# Altium schematic sheet GradientFilter.SchDoc
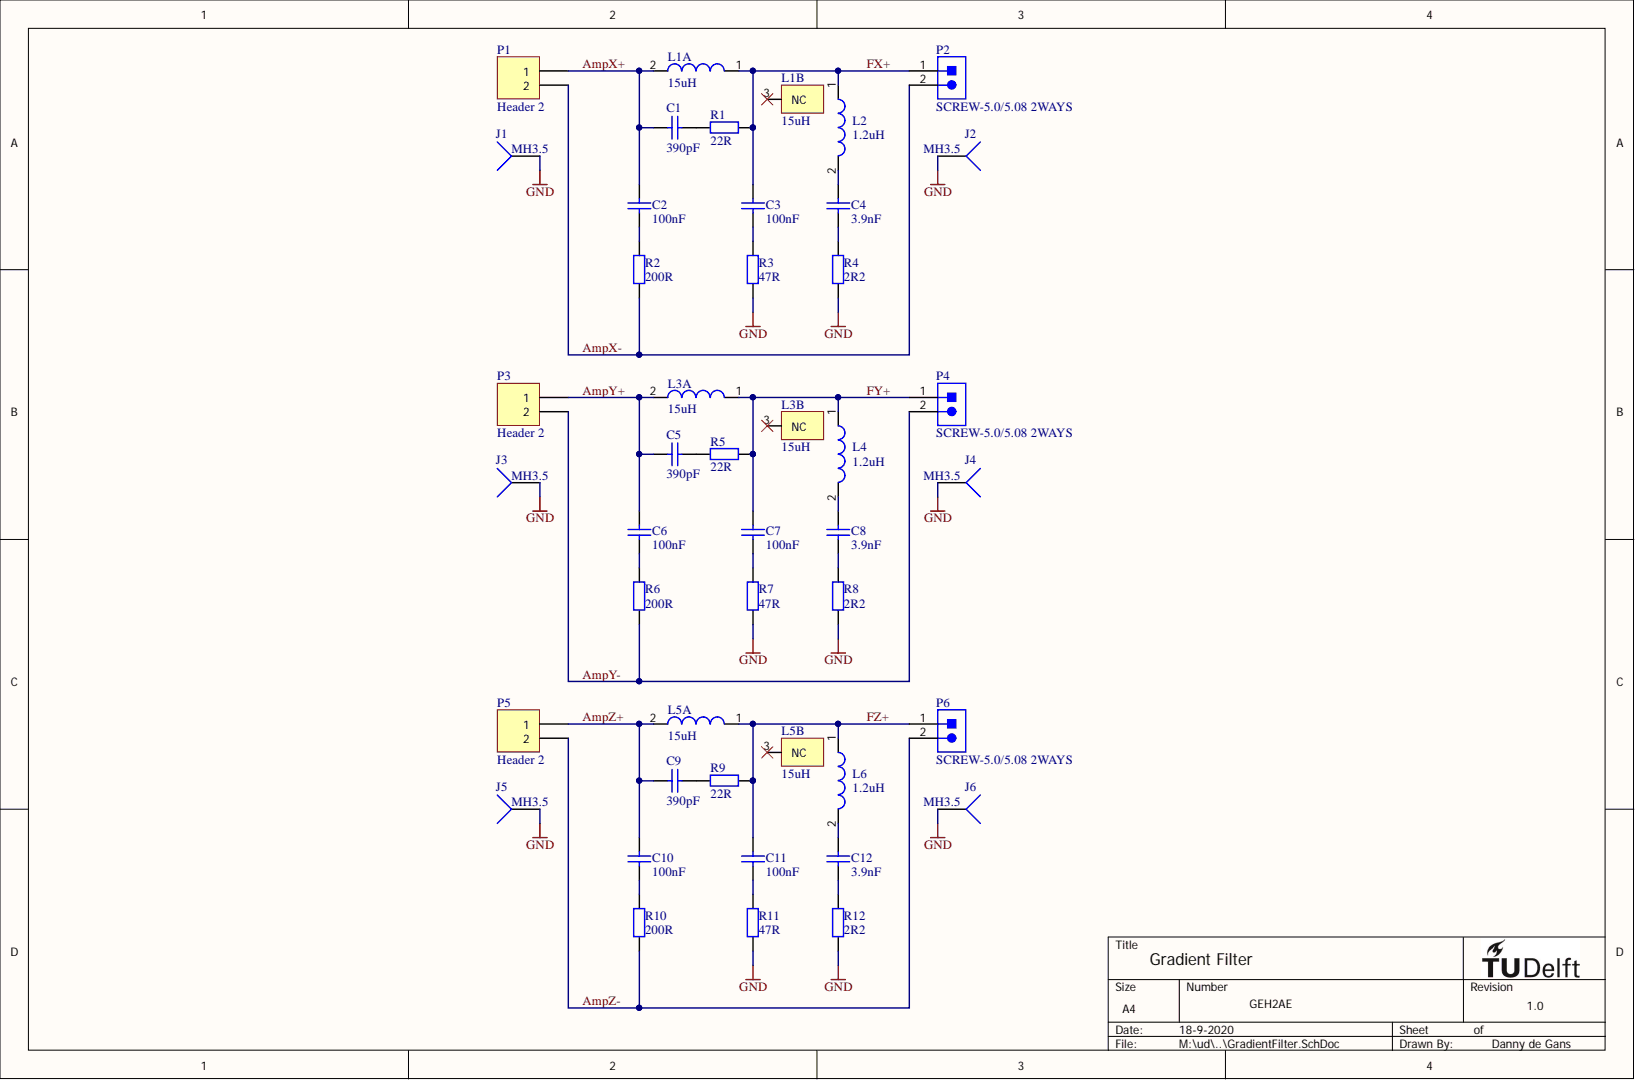
[diagram p0: GradientFilter.SchDoc]

## Parts
C1  390pF  [SMD/C_0805]
C2  100nF  [1812]
C3  100nF  [1812]
C4  3.9nF  [SMD/C_2225]
C5  390pF  [SMD/C_0805]
C6  100nF  [1812]
C7  100nF  [1812]
C8  3.9nF  [SMD/C_2225]
C9  390pF  [SMD/C_0805]
C10  100nF  [1812]
C11  100nF  [1812]
C12  3.9nF  [SMD/C_2225]
J1  MH3.5  [MTHOLE3.5MM_TOPBOT7MM]
J2  MH3.5  [MTHOLE3.5MM_TOPBOT7MM]
J3  MH3.5  [MTHOLE3.5MM_TOPBOT7MM]
J4  MH3.5  [MTHOLE3.5MM_TOPBOT7MM]
J5  MH3.5  [MTHOLE3.5MM_TOPBOT7MM]
J6  MH3.5  [MTHOLE3.5MM_TOPBOT7MM]
L1  15uH  [WE-HCF 2818]  (parts A,B)
L2  1.2uH  [WE-TPC-4828-MH]
L3  15uH  [WE-HCF 2818]  (parts A,B)
L4  1.2uH  [WE-TPC-4828-MH]
L5  15uH  [WE-HCF 2818]  (parts A,B)
L6  1.2uH  [WE-TPC-4828-MH]
P1  Header 2  [WireToBoard_H2mm_P5mm]
P2  SCREW-5.0/5.08 2WAYS  [SCREW-5.0-2WAYS_V]
P3  Header 2  [WireToBoard_H2mm_P5mm]
P4  SCREW-5.0/5.08 2WAYS  [SCREW-5.0-2WAYS_V]
P5  Header 2  [WireToBoard_H2mm_P5mm]
P6  SCREW-5.0/5.08 2WAYS  [SCREW-5.0-2WAYS_V]
R1  22R  [SMD/RF_0805]
R2  200R  [SMD/R_2512]
R3  47R  [SMD/R_2512]
R4  2R2  [SMD/R_2512]
R5  22R  [SMD/RF_0805]
R6  200R  [SMD/R_2512]
R7  47R  [SMD/R_2512]
R8  2R2  [SMD/R_2512]
R9  22R  [SMD/RF_0805]
R10  200R  [SMD/R_2512]
R11  47R  [SMD/R_2512]
R12  2R2  [SMD/R_2512]

## Nets
GND: J1.1 J2.1 J3.1 J4.1 J5.1 J6.1 R3.2 R4.2 R7.2 R8.2 R11.2 R12.2
AmpX+: C1.1 C2.2 L1.2 P1.1
AmpX-: P1.2 P2.2 R2.2
AmpY+: C5.1 C6.2 L3.2 P3.1
AmpY-: P3.2 P4.2 R6.2
AmpZ+: C9.1 C10.2 L5.2 P5.1
AmpZ-: P5.2 P6.2 R10.2
FX+: C3.2 L1.1 L2.1 P2.1 R1.1
FY+: C7.2 L3.1 L4.1 P4.1 R5.1
FZ+: C11.2 L5.1 L6.1 P6.1 R9.1
NetC1_2: C1.2 R1.2
NetC2_1: C2.1 R2.1
NetC3_1: C3.1 R3.1
NetC4_1: C4.1 R4.1
NetC4_2: C4.2 L2.2
NetC5_2: C5.2 R5.2
NetC6_1: C6.1 R6.1
NetC7_1: C7.1 R7.1
NetC8_1: C8.1 R8.1
NetC8_2: C8.2 L4.2
NetC9_2: C9.2 R9.2
NetC10_1: C10.1 R10.1
NetC11_1: C11.1 R11.1
NetC12_1: C12.1 R12.1
NetC12_2: C12.2 L6.2

Not connected: L1 3(NC); L3 3(NC); L5 3(NC)

## Library data of the parts
C1 C5 C9 = Cap: Capacitor; MPN: 08051A391JAT2A; Component Kind: Standard; LatestRevisionDate: 17-Jul-2002; LatestRevisionNote: Re-released for DXP Platform.; Library Name: Miscellaneous Devices.IntLib; PackageDescription: Radial Cap, Thru-Hole; 2 Leads; 0.3 in Pin Spacing; PackageReference: RAD-0.3; Pin Info: NP0, 100V, 5%; Published: 8-Jun-2000; Signal Integrity: Cap; Simulation: CAP; Value: 390pF; Publisher: Altium Limited
C2 C3 C6 C7 C10 C11 = Cap: Capacitor; MPN: C1812C104J1GACTU; Component Kind: Standard; LatestRevisionDate: 17-Jul-2002; LatestRevisionNote: Re-released for DXP Platform.; Library Name: Miscellaneous Devices.IntLib; PackageDescription: Radial Cap, Thru-Hole; 2 Leads; 0.3 in Pin Spacing; PackageReference: RAD-0.3; Pin Info: NP0, 100V, 5%; Published: 8-Jun-2000; Signal Integrity: Cap; Simulation: CAP; Value: 100nF; Publisher: Altium Limited
C4 C8 C12 = Cap: Capacitor; MPN: C2225C392JGGACTU; Component Kind: Standard; LatestRevisionDate: 17-Jul-2002; LatestRevisionNote: Re-released for DXP Platform.; Library Name: Miscellaneous Devices.IntLib; PackageDescription: Radial Cap, Thru-Hole; 2 Leads; 0.3 in Pin Spacing; PackageReference: RAD-0.3; Pin Info: NP0, 2kV, 5%; Published: 8-Jun-2000; Signal Integrity: Cap; Simulation: CAP; Value: 3.9nF; Publisher: Altium Limited
J1-J6 = Socket: MPN: M3 brass washer; Component Kind: Standard; Description: Socket; LatestRevisionDate: 17-Jul-2002; LatestRevisionNote: Re-released for DXP Platform.; Library Name: Miscellaneous Connectors.IntLib; Pin Info: mounting hole; Signal Integrity: Connector; Value: MH3.5; Publisher: Altium Limited
L1 L3 L5 = CMP-1378-00012-1: SMD High Current Inductor WE-HCF, L=15µH; Manufacturer: Wuerth Elektronik; MPN: 7443641500; Inductance: 15ÂµH; Component Kind: Standard; ComponentLink1Description: Manufacturer URL; ComponentLink1URL: http://www.we-online.com; ComponentLink2Description: Datasheet; ComponentLink2URL: http://katalog.we-online.de/kataloge/eisos/media/pdf/7443641500.pdf; Library Name: Altium Content Vault; PackageReference: WE-HCF 2818; PartNumber: 7443641500; Pin Info: 26A, 2.4mR, 11MHz; Value: 15uH
L2 L4 L6 = CMP-0227-00340-1: SMD Shielded Tiny Power Inductor WE-TPC, L = 1.20 µH; MPN: 7440430012; Inductance: 1.20 ÂµH; Component Kind: Standard; ComponentLink1Description: Manufacturer Link; ComponentLink1URL: http://www.we-online.com; ComponentLink2Description: Datasheet; ComponentLink2URL: http://www.we-online.de/katalog/media/pdf/7440430012.pdf; DatasheetVersion: ver 6, Aug-2010; Library Name: Altium Content Vault; PackageDescription: SMD Inductor, 2-Leads, Body 4.8 x 4.8 mm; PackageReference: WE-TPC-4828-MH; PackageVersion: 2010; Pin Info: 2.8A, 20mR, 150MHz; Value: 1.2uH
P1 P3 P5 = Header 2: Header, 2-Pin; Component Kind: Standard; LatestRevisionDate: 17-Jul-2002; LatestRevisionNote: Re-released for DXP Platform.; Library Name: Miscellaneous Connectors.IntLib; Pin Info: 2mm hole, 5mm pitch; Signal Integrity: Connector; Value: Header 2; Publisher: Altium Limited
P2 P4 P6 = CMP-0617-00009-1: Socket, Screw Terminal Block, 2 Way, 5.0 mm Pitch, 90 Degree Angle, Through-Hole; Manufacturer: No Vendor; MPN: 20020316-G021B01LF; Contact Resistance: 20 mOhm; Insulation Resistance: 5000 MOhm / 1000 V; Poles: 2; Rated Current: 25 A; Rated Voltage: 300 V; Component Kind: Standard; ComponentLink1Description: Datasheet; ComponentLink1URL: 5mm screw terminal in SVN; Library Name: Altium Content Vault; Mounting Technology: Through Hole; PackageDescription: Socket, Screw Terminal Block, 2 Way, Pitch 5 mm, 90 Degree Angle, Through-Hole; PartNumber: SCREW-5.0/5.08 2WAYS; Pin Info: 16A, 12-28AWG, 5mm; Temperature Range: -40 to 105 degC; Value: SCREW-5.0/5.08 2WAYS
R1 R5 R9 = Res2: Resistor; MPN: ERJP06F22R0V; Component Kind: Standard; LatestRevisionDate: 17-Jul-2002; LatestRevisionNote: Re-released for DXP Platform.; Library Name: Miscellaneous Devices.IntLib; PackageDescription: Axial Device, Thru-Hole; 2 Leads; 0.4 in Pin Spacing; PackageReference: AXIAL-0.4; Pin Info: 500mW, 1%, anti surge; Published: 8-Jun-2000; Signal Integrity: Res; Simulation: RESISTOR; Value: 22R; Publisher: Altium Limited
R2 R6 R10 = Res2: Resistor; MPN: CRCW2512200RFKEG; Component Kind: Standard; LatestRevisionDate: 17-Jul-2002; LatestRevisionNote: Re-released for DXP Platform.; Library Name: Miscellaneous Devices.IntLib; PackageDescription: Axial Device, Thru-Hole; 2 Leads; 0.4 in Pin Spacing; PackageReference: AXIAL-0.4; Pin Info: 1W, 1%, thick film; Published: 8-Jun-2000; Signal Integrity: Res; Simulation: RESISTOR; Value: 200R; Publisher: Altium Limited
R3 R7 R11 = Res2: Resistor; MPN: 352247RFT; Component Kind: Standard; LatestRevisionDate: 17-Jul-2002; LatestRevisionNote: Re-released for DXP Platform.; Library Name: Miscellaneous Devices.IntLib; PackageDescription: Axial Device, Thru-Hole; 2 Leads; 0.4 in Pin Spacing; PackageReference: AXIAL-0.4; Pin Info: 3W, 1%, thick film; Published: 8-Jun-2000; Signal Integrity: Res; Simulation: RESISTOR; Value: 47R; Publisher: Altium Limited
R4 R8 R12 = Res2: Resistor; MPN: 35222R2JT; Component Kind: Standard; LatestRevisionDate: 17-Jul-2002; LatestRevisionNote: Re-released for DXP Platform.; Library Name: Miscellaneous Devices.IntLib; PackageDescription: Axial Device, Thru-Hole; 2 Leads; 0.4 in Pin Spacing; PackageReference: AXIAL-0.4; Pin Info: 3W, 5%, thick film; Published: 8-Jun-2000; Signal Integrity: Res; Simulation: RESISTOR; Value: 2R2; Publisher: Altium Limited
